# Altium schematic sheet Display.SchDoc
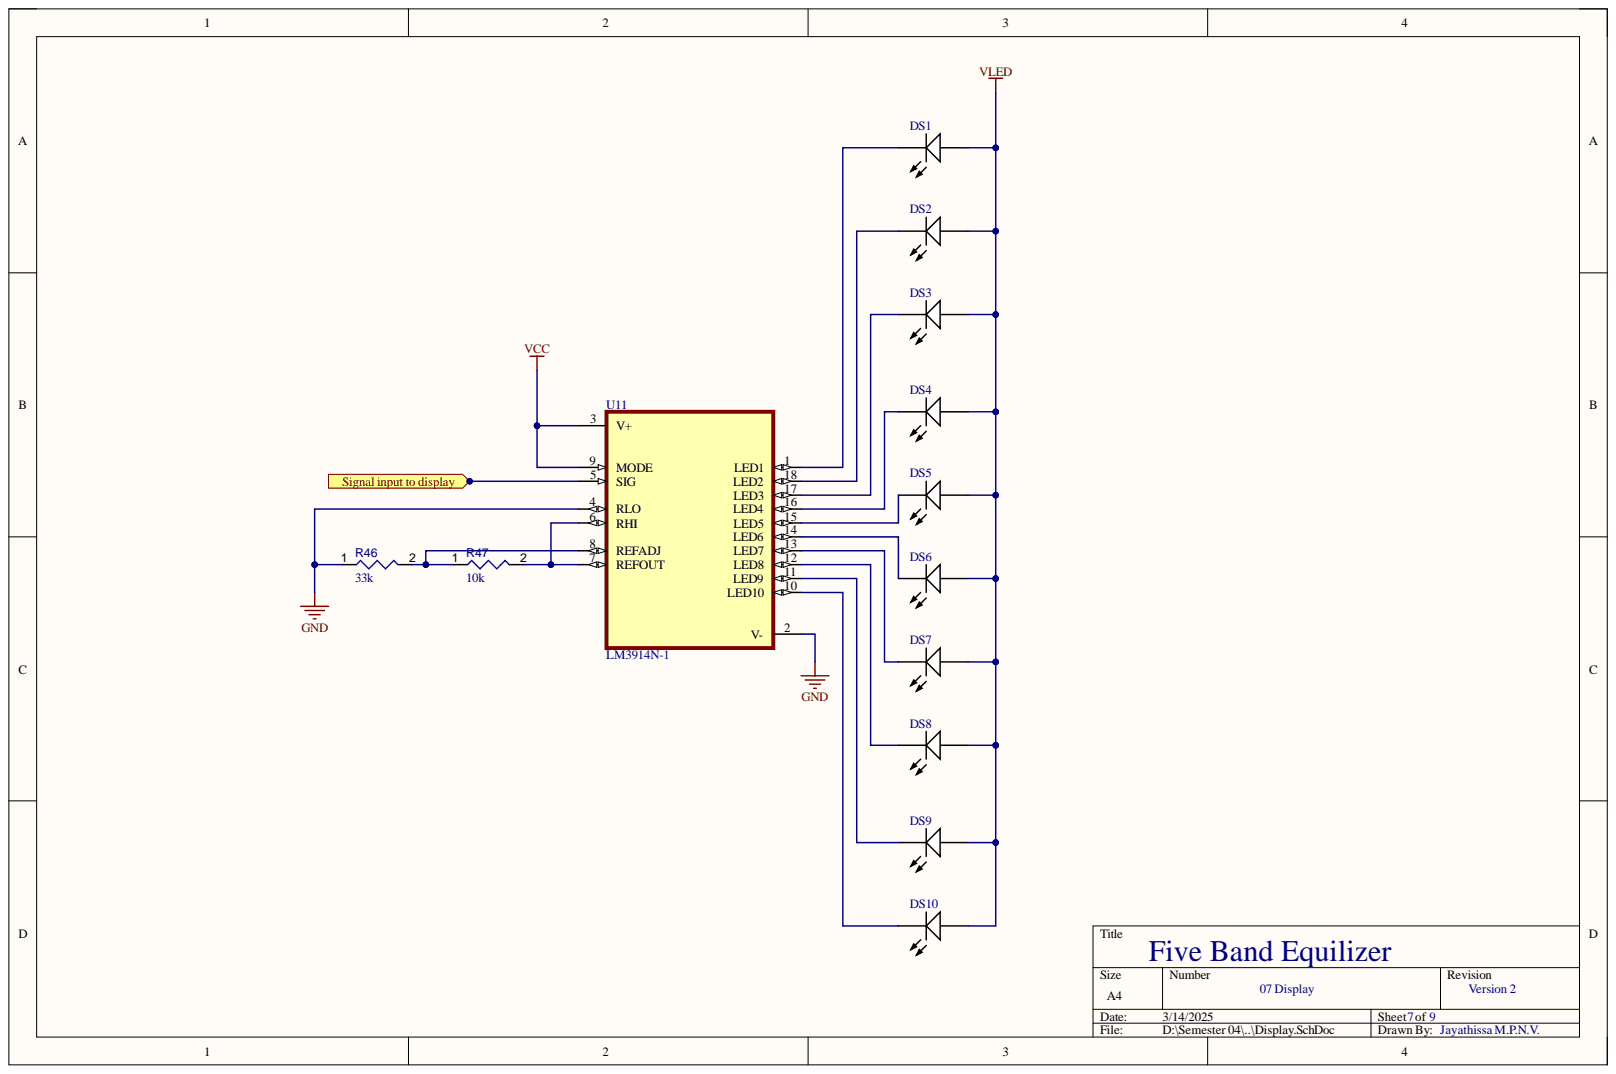
[diagram p0: Display.SchDoc]

## Parts
DS1  16-219A/T2D-AR2T1QY/3T  [EVRL-0402-2_V]  (value not drawn)
DS2  16-219A/T2D-AR2T1QY/3T  [EVRL-0402-2_V]  (value not drawn)
DS3  16-219A/T2D-AR2T1QY/3T  [EVRL-0402-2_V]  (value not drawn)
DS4  16-219A/T2D-AR2T1QY/3T  [EVRL-0402-2_V]  (value not drawn)
DS5  16-219A/T2D-AR2T1QY/3T  [EVRL-0402-2_V]  (value not drawn)
DS6  16-219A/T2D-AR2T1QY/3T  [EVRL-0402-2_V]  (value not drawn)
DS7  16-219A/T2D-AR2T1QY/3T  [EVRL-0402-2_V]  (value not drawn)
DS8  16-219A/T2D-AR2T1QY/3T  [EVRL-0402-2_V]  (value not drawn)
DS9  16-219A/T2D-AR2T1QY/3T  [EVRL-0402-2_V]  (value not drawn)
DS10  16-219A/T2D-AR2T1QY/3T  [EVRL-0402-2_V]  (value not drawn)
R46  value 33k  [RESC1005X40X25ML10T10]  (hidden comment: Resistor 33k +/-5% 0402 63 mW)
R47  value 10k  [RESC0402(1005)_L]  (hidden comment: Resistor 10K  +/-1 % 0402 63 mW)
U11  LM3914N-1  [DIP952W45P254L2336H533Q18]

## Ports
Signal input to display

## Nets
GND: R46.1 U11.2(V-) U11.4(RLO)
VCC: U11.3(V+) U11.9(MODE)
VLED: DS1.1 DS2.1 DS3.1 DS4.1 DS5.1 DS6.1 DS7.1 DS8.1 DS9.1 DS10.1
Signal input to display: U11.5(SIG) ; port Signal input to display
NetDS1_2: DS1.2 U11.1(LED1)
NetDS2_2: DS2.2 U11.18(LED2)
NetDS3_2: DS3.2 U11.17(LED3)
NetDS4_2: DS4.2 U11.16(LED4)
NetDS5_2: DS5.2 U11.15(LED5)
NetDS6_2: DS6.2 U11.14(LED6)
NetDS7_2: DS7.2 U11.13(LED7)
NetDS8_2: DS8.2 U11.12(LED8)
NetDS9_2: DS9.2 U11.11(LED9)
NetDS10_2: DS10.2 U11.10(LED10)
NetR46_2: R46.2 R47.1 U11.8(REFADJ)
NetR47_2: R47.2 U11.6(RHI) U11.7(REFOUT)

## Library data of the parts
DS1-DS10 = CMP-007-00000-2: Chip LED, White, 5 V, 10 mA, 30 mW, -40 to 85 degC, 2-Pin SMD (0402), RoHS, Tape and Reel; Manufacturer: Everlight Electronics; Forward Current: 5mA; Forward Voltage: 2.6V; Luminous Intensity: 360mcd; Power: 30mW; Viewing Angle: 130degrees; Case/Package: 0402; Component Kind: Standard; Datasheet URL: http://www.everlight.com/file/ProductFile/16-219A-T2D-AR2T1QY-3T.pdf; Datasheet Version: Rev. 5, 04/2013; Illumination Colour: White; Manufacturer URL: http://www.everlight.com/; Max Operating Temperature: 85°C; Min Operating Temperature: -40°C; Mounting Technology: SM; Package Description: 2-Pin Surface Mount Device, Body 1 x 0.5 mm; Package Quantity: 3000; Package Reference: 0402; Packaging: TapeandReel; RoHS Compliant: True; Library Name: Nirwan Jayathissa
R46 = CMP-009-00028-5: Chip Resistor, 33 KOhm, +/- 5%, 0.063 W, -55 to 155 degC, 0402 (1005 Metric); Power: 63mW; Case/Package: 0402; Component Kind: Standard; Max Operating Temperature: 155°C; Min Operating Temperature: -55°C; Mounting Technology: SM; Pins: 2; RoHS Compliant: True; Tolerance: 5%; Library Name: Nirwan Jayathissa
R47 = CMP-009-00149-3: Chip Resistor, 10 kOhms, +/-1 %, 63 mW, -55 to 125 degC, 0402; Power: 63mW; Tolerance: 1%; Case/Package: 0402; Component Kind: Standard; Max Operating Temperature: 155°C; Min Operating Temperature: -55°C; Pins: 2; Library Name: Nirwan Jayathissa
U11 = CMP-017-000000-1: None <a href="https://snapeda.com/parts/LM3914N-1/Texas%20Instruments/view-part/?ref=eda">Check prices</a>; Availability: Unavailable; Component Kind: Standard; Component Type: Standard; Design Item ID: LM3914N-1; MP: LM3914N-1; Package: None; Pin Count: 18; Price: None; Source: LM3914N-1.SchLib; Library Name: Nirwan Jayathissa
